# Altium schematic sheet Main.SchDoc
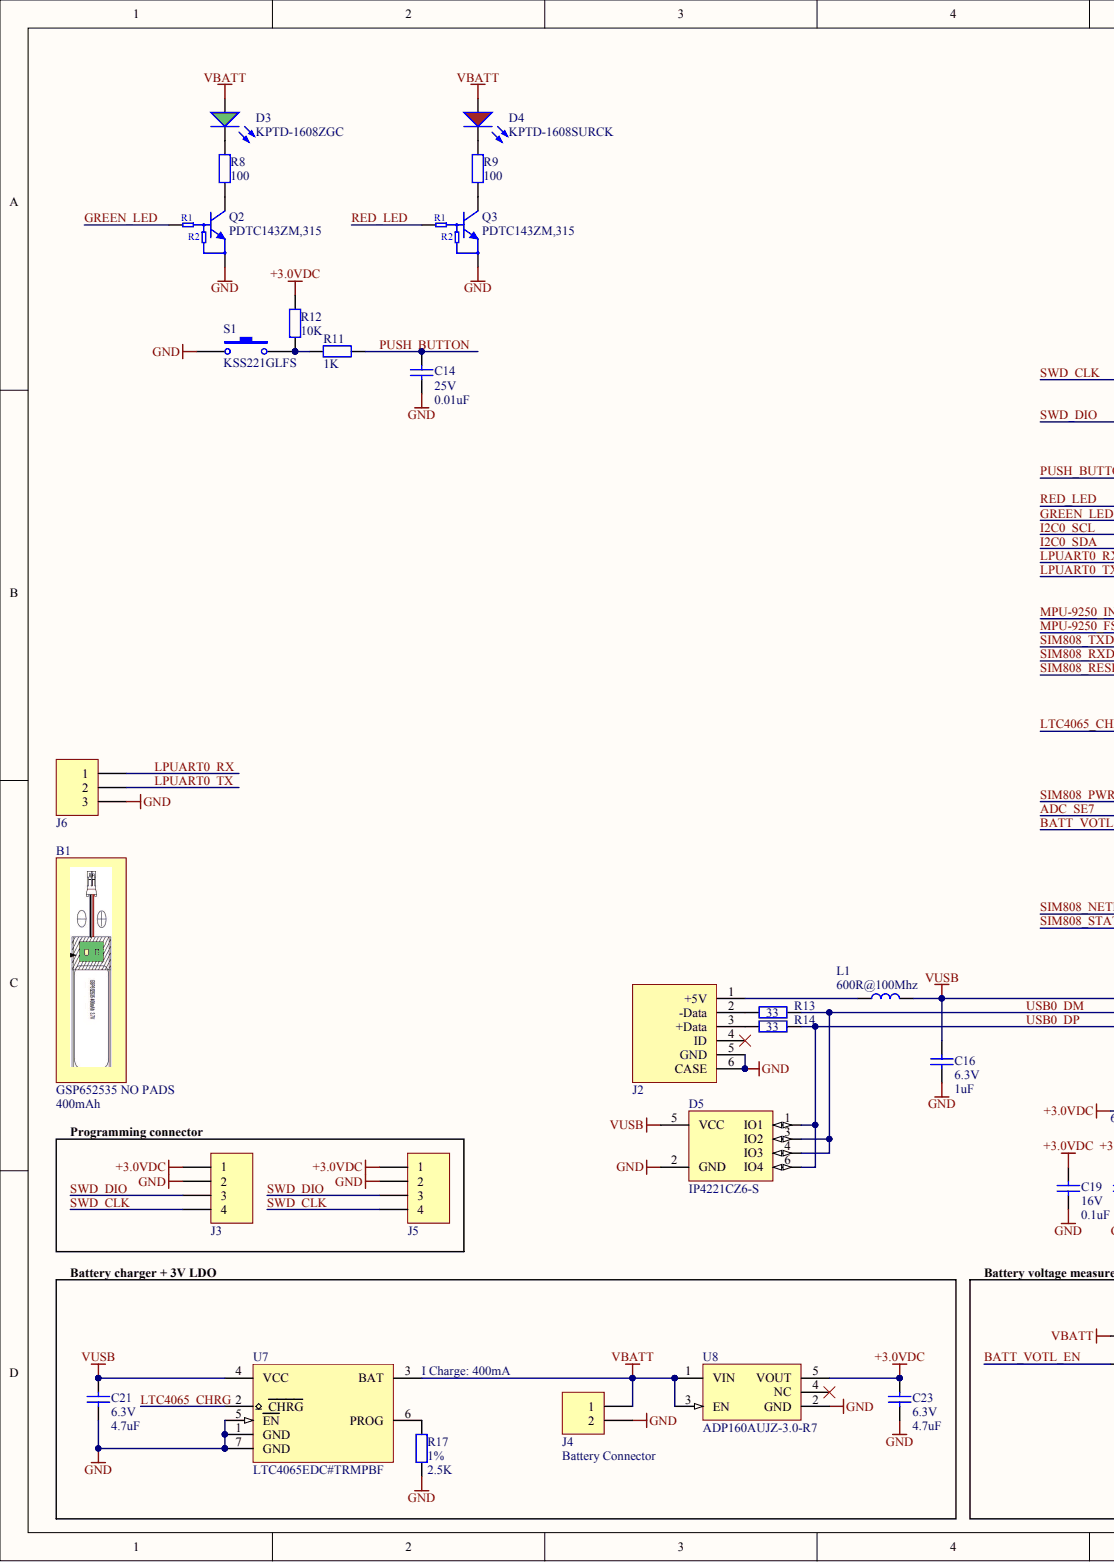
[diagram p0: Main.SchDoc, left]
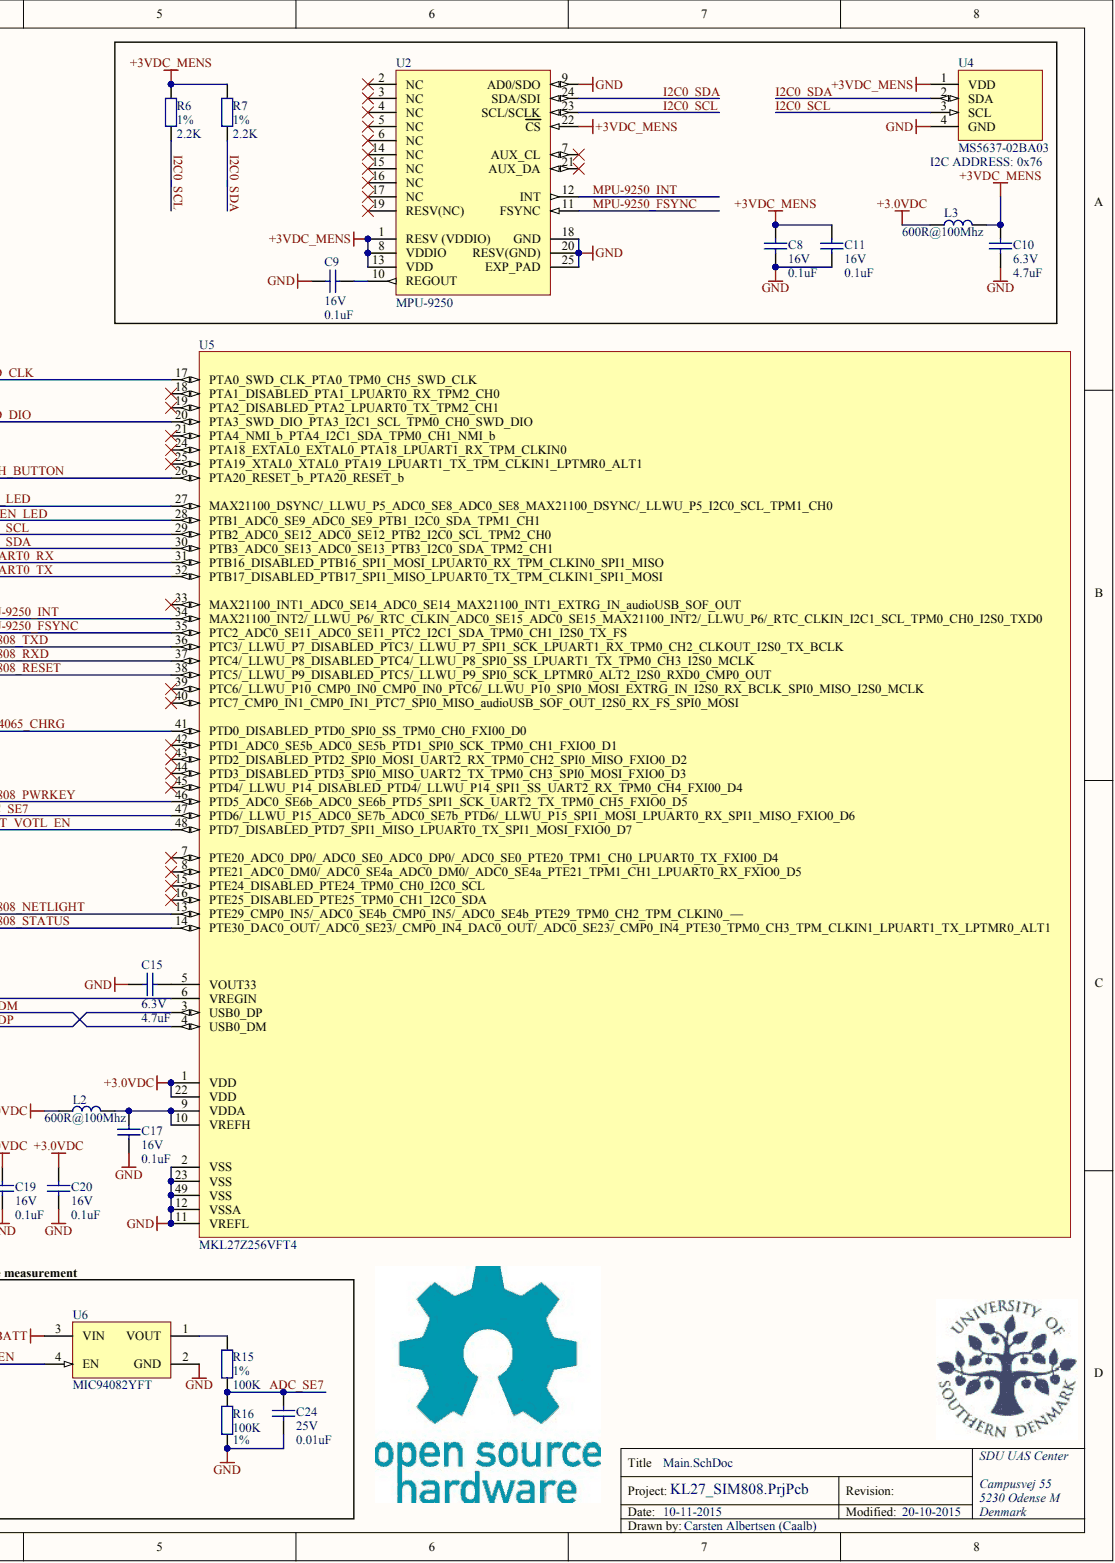
[diagram p1: Main.SchDoc, right]

## Parts
B1  GSP652535 NO PADS  [GSP652535 NO PADS 2.6mm up]
C8  16V  value 0.1uF  [0402C]
C9  16V  value 0.1uF  [0402C]
C10  6.3V  value 4.7uF  [0402C]
C11  16V  value 0.1uF  [0402C]
C14  25V  value 0.01uF  [0402C]
C15  6.3V  value 4.7uF  [0402C]
C16  6.3V  value 1uF  [0402C]
C17  16V  value 0.1uF  [0402C]
C19  16V  value 0.1uF  [0402C]
C20  16V  value 0.1uF  [0402C]
C21  6.3V  value 4.7uF  [0402C]
C23  6.3V  value 4.7uF  [0402C]
C24  25V  value 0.01uF  [0402C]
D3  KPTD-1608ZGC  [LED0603G]
D4  KPTD-1608SURCK  [LED0603R]
D5  IP4221CZ6-S  [SOT886]
J2  Micro USB B  [47346-0001]  (value not drawn)
J3  1x4 Header  [4_Progo_Pin_1.27]  (value not drawn)
J4  1x2 Header  [2x1 Pads 2x2mm SMD]  (value not drawn)
J5  1x4 Header  [4 x 2.54 Pads TOP]  (value not drawn)
J6  1x3 Header  [3 x 2.54 Pads TOP]  (value not drawn)
L1  value 600R@100Mhz  [0402L]  (hidden comment: BK1005HW601-T)
L2  value 600R@100Mhz  [0402L]  (hidden comment: BK1005HW601-T)
L3  value 600R@100Mhz  [0402L]  (hidden comment: BK1005HW601-T)
Q2  PDTC143ZM,315  [SOT883]
Q3  PDTC143ZM,315  [SOT883]
R6  1%  value 2.2K  [0402R]
R7  1%  value 2.2K  [0402R]
R8  1%  value 100  [0402R]
R9  1%  value 100  [0402R]
R11  1%  value 1K  [0402R]
R12  1%  value 10K  [0402R]
R13  value 33  [0402R]  (hidden comment: 1%)
R14  value 33  [0402R]  (hidden comment: 1%)
R15  1%  value 100K  [0402R]
R16  1%  value 100K  [0402R]
R17  1%  value 2.5K  [0402R]
S1  KSS221GLFS  [KSS221G LFS]
U2  MPU-9250  [QFN40P300X300X100_HS-25N]
U4  MS5637-02BA03  [MS5637]
U5  MKL27Z256VFT4  [qfn48 7x7]
U6  MIC94082YFT  [MLF 0.85x0.85]
U7  LTC4065EDC#TRMPBF  [DFN 6 2X2]
U8  ADP160AUJZ-3.0-R7  [SOT23-5]

## Nets
+3.0VDC: C19.2 C20.2 C23.1 J3.1 J5.1 L2.1 L3.1 R12.1 U5.1(VDD) U5.22(VDD) U8.5(VOUT)
+3VDC_MENS: C8.2 C10.2 C11.2 L3.2 R6.1 R7.1 U2.1(RESV_(VDDIO)) U2.8(VDDIO) U2.13(VDD) U2.22(CS) U4.1(VDD)
GND: C8.1 C9.1 C10.1 C11.1 C14.1 C15.2 C16.1 C17.2 C19.1 C20.1 C21.2 C23.2 C24.1 D5.2(GND) J2.5(GND) J2.6(CASE) J3.2 J4.2 J5.2 J6.3 Q2.2 Q3.2 R16.2 R17.2 S1.2 U2.9(AD0/SDO) U2.18(GND) U2.20(RESV(GND)) U2.25(EXP_PAD) U4.4(GND) U5.2(VSS) U5.11(VREFL) U5.12(VSSA) U5.23(VSS) U5.49(VSS) U6.2(GND) U7.1(GND) U7.5(EN) U7.7(GND) U8.2(GND)
VBATT: D3.1 D4.1 J4.1 U6.3(VIN) U7.3(BAT) U8.1(VIN) U8.3(EN)
VUSB: C16.2 C21.1 D5.5(VCC) L1.2 U5.6(VREGIN) U7.4(VCC)
ADC_SE7: C24.2 R15.2 R16.1 U5.47(PTD6/_LLWU_P15_ADC0_SE7b_ADC0_SE7b_PTD6/_LLWU_P15_SPI1_MOSI_LPUART0_RX_SPI1_MISO_FXIO0_D6)
BATT_VOTL_EN: U5.48(PTD7_DISABLED_PTD7_SPI1_MISO_LPUART0_TX_SPI1_MOSI_FXIO0_D7) U6.4(EN)
GREEN_LED: Q2.1 U5.28(PTB1_ADC0_SE9_ADC0_SE9_PTB1_I2C0_SDA_TPM1_CH1)
I2C0_SCL: R6.2 U2.23(SCL/SCLK) U4.3(SCL) U5.29(PTB2_ADC0_SE12_ADC0_SE12_PTB2_I2C0_SCL_TPM2_CH0)
I2C0_SDA: R7.2 U2.24(SDA/SDI) U4.2(SDA) U5.30(PTB3_ADC0_SE13_ADC0_SE13_PTB3_I2C0_SDA_TPM2_CH1)
LPUART0_RX: J6.1 U5.31(PTB16_DISABLED_PTB16_SPI1_MOSI_LPUART0_RX_TPM_CLKIN0_SPI1_MISO)
LPUART0_TX: J6.2 U5.32(PTB17_DISABLED_PTB17_SPI1_MISO_LPUART0_TX_TPM_CLKIN1_SPI1_MOSI)
LTC4065_CHRG: U5.41(PTD0_DISABLED_PTD0_SPI0_SS_TPM0_CH0_FXI00_D0) U7.2(CHRG)
MPU-9250_FSYNC: U2.11(FSYNC) U5.35(PTC2_ADC0_SE11_ADC0_SE11_PTC2_I2C1_SDA_TPM0_CH1_I2S0_TX_FS)
MPU-9250_INT: U2.12(INT) U5.34(MAX21100_INT2/_LLWU_P6/_RTC_CLKIN_ADC0_SE15_ADC0_SE15_MAX21100_INT2/_LLWU_P6/_RTC_CLKIN_I2C1_SCL_TPM0_CH0_I2S0_TXD0)
PUSH_BUTTON: C14.2 R11.2 U5.26(PTA20_RESET_b_PTA20_RESET_b)
RED_LED: Q3.1 U5.27(MAX21100_DSYNC/_LLWU_P5_ADC0_SE8_ADC0_SE8_MAX21100_DSYNC/_LLWU_P5_I2C0_SCL_TPM1_CH0)
SIM808_NETLIGHT: U5.13(PTE29_CMP0_IN5/_ADC0_SE4b_CMP0_IN5/_ADC0_SE4b_PTE29_TPM0_CH2_TPM_CLKIN0_—)
SIM808_PWRKEY: U5.46(PTD5_ADC0_SE6b_ADC0_SE6b_PTD5_SPI1_SCK_UART2_TX_TPM0_CH5_FXIO0_D5)
SIM808_RESET: U5.38(PTC5/_LLWU_P9_DISABLED_PTC5/_LLWU_P9_SPI0_SCK_LPTMR0_ALT2_I2S0_RXD0_CMP0_OUT)
SIM808_RXD: U5.37(PTC4/_LLWU_P8_DISABLED_PTC4/_LLWU_P8_SPI0_SS_LPUART1_TX_TPM0_CH3_I2S0_MCLK)
SIM808_STATUS: U5.14(PTE30_DAC0_OUT/_ADC0_SE23/_CMP0_IN4_DAC0_OUT/_ADC0_SE23/_CMP0_IN4_PTE30_TPM0_CH3_TPM_CLKIN1_LPUART1_TX_LPTMR0_ALT1)
SIM808_TXD: U5.36(PTC3/_LLWU_P7_DISABLED_PTC3/_LLWU_P7_SPI1_SCK_LPUART1_RX_TPM0_CH2_CLKOUT_I2S0_TX_BCLK)
SWD_CLK: J3.4 J5.4 U5.17(PTA0_SWD_CLK_PTA0_TPM0_CH5_SWD_CLK)
SWD_DIO: J3.3 J5.3 U5.20(PTA3_SWD_DIO_PTA3_I2C1_SCL_TPM0_CH0_SWD_DIO)
USB0_DM: D5.3(IO2) D5.4(IO3) R13.2 U5.4(USB0_DM)
USB0_DP: D5.1(IO1) D5.6(IO4) R14.2 U5.3(USB0_DP)
NetC9_2: C9.2 U2.10(REGOUT)
NetC15_1: C15.1 U5.5(VOUT33)
NetC17_1: C17.1 L2.2 U5.9(VDDA) U5.10(VREFH)
NetD3_2: D3.2 R8.1
NetD4_2: D4.2 R9.1
NetJ2_1: J2.1(+5V) L1.1
NetJ2_2: J2.2(-Data) R13.1
NetJ2_3: J2.3(+Data) R14.1
NetQ2_3: Q2.3 R8.2
NetQ3_3: Q3.3 R9.2
NetR11_1: R11.1 R12.2 S1.1
NetR15_1: R15.1 U6.1(VOUT)
NetR17_1: R17.1 U7.6(PROG)

Not connected: J2 4(ID); U2 2(NC) 3(NC) 4(NC) 5(NC) 6(NC) 7(AUX_CL) 14(NC) 15(NC) 16(NC) 17(NC) 19(RESV(NC)) 21(AUX_DA); U5 7(PTE20_ADC0_DP0/_ADC0_SE0_ADC0_DP0/_ADC0_SE0_PTE20_TPM1_CH0_LPUART0_TX_FXI00_D4) 8(PTE21_ADC0_DM0/_ADC0_SE4a_ADC0_DM0/_ADC0_SE4a_PTE21_TPM1_CH1_LPUART0_RX_FXIO0_D5) 15(PTE24_DISABLED_PTE24_TPM0_CH0_I2C0_SCL) 16(PTE25_DISABLED_PTE25_TPM0_CH1_I2C0_SDA) 18(PTA1_DISABLED_PTA1_LPUART0_RX_TPM2_CH0) 19(PTA2_DISABLED_PTA2_LPUART0_TX_TPM2_CH1) 21(PTA4_NMI_b_PTA4_I2C1_SDA_TPM0_CH1_NMI_b) 24(PTA18_EXTAL0_EXTAL0_PTA18_LPUART1_RX_TPM_CLKIN0) 25(PTA19_XTAL0_XTAL0_PTA19_LPUART1_TX_TPM_CLKIN1_LPTMR0_ALT1) 33(MAX21100_INT1_ADC0_SE14_ADC0_SE14_MAX21100_INT1_EXTRG_IN_audioUSB_SOF_OUT) 39(PTC6/_LLWU_P10_CMP0_IN0_CMP0_IN0_PTC6/_LLWU_P10_SPI0_MOSI_EXTRG_IN_I2S0_RX_BCLK_SPI0_MISO_I2S0_MCLK) 40(PTC7_CMP0_IN1_CMP0_IN1_PTC7_SPI0_MISO_audioUSB_SOF_OUT_I2S0_RX_FS_SPI0_MOSI) 42(PTD1_ADC0_SE5b_ADC0_SE5b_PTD1_SPI0_SCK_TPM0_CH1_FXIO0_D1) 43(PTD2_DISABLED_PTD2_SPI0_MOSI_UART2_RX_TPM0_CH2_SPI0_MISO_FXIO0_D2) 44(PTD3_DISABLED_PTD3_SPI0_MISO_UART2_TX_TPM0_CH3_SPI0_MOSI_FXIO0_D3) 45(PTD4/_LLWU_P14_DISABLED_PTD4/_LLWU_P14_SPI1_SS_UART2_RX_TPM0_CH4_FXI00_D4); U8 4(NC)

## Library data of the parts
C8 C9 C11 C17 C19 C20 = Cap_0402_.1uF: Capacitor, SMD, 0402, X7R; Component Kind: Standard; Signal Integrity: Cap; Simulation: CAP; Supplier 1: RS-Components; Supplier 2: Farnell; Supplier 3: Digi-Key; Supplier 4: Mouser; Library Name: Ofte_Benyttede.SCHLIB
C10 C21 C23 = Cap_0402_4.7uF: Capacitor, SMD, 0402, X5R; Component Kind: Standard; Signal Integrity: Cap; Simulation: CAP; Supplier 1: RS-Components; Supplier 2: Farnell; Supplier 3: Digi-Key; Supplier 4: Mouser; Supplier Part Number 1: 758-2764; Supplier Part Number 3: 1276-1056-1-ND; Library Name: Ofte_Benyttede.SCHLIB
C14 C24 = Cap_0402_.01uF: Capacitor, SMD, 0402, X7R; Component Kind: Standard; Signal Integrity: Cap; Simulation: CAP; Supplier 1: RS-Components; Supplier 2: Farnell; Supplier 3: Digi-Key; Supplier 4: Mouser; Supplier Part Number 2: 2070373; Library Name: Ofte_Benyttede.SCHLIB
C15 = Cap_0402_4.7uF: Capacitor, SMD, 0402, X5R; Value: 4.7uF; Component Kind: Standard; Signal Integrity: Cap; Simulation: CAP; Supplier 1: RS-Components; Supplier 2: Farnell; Supplier 3: Digi-Key; Supplier 4: Mouser; Supplier Part Number 1: 758-2764; Supplier Part Number 3: 1276-1056-1-ND; Library Name: Ofte_Benyttede.SCHLIB
C16 = Cap_0402_1uF: Capacitor, SMD, 0402, X5R; Component Kind: Standard; Signal Integrity: Cap; Simulation: CAP; Supplier 1: RS-Components; Supplier 2: Farnell; Supplier 3: Digi-Key; Supplier 4: Mouser; Library Name: Ofte_Benyttede.SCHLIB
D3 = LED_Green: LED,SMD,True-Green, 1000mcd@20mA; Lager: No; Component Kind: Standard; PN Farnell: 2217986; Library Name: Ofte_Benyttede.SCHLIB
D4 = LED_Red: LED,SMD,Hyper Red, 800mcd@20mA; Lager: No; Component Kind: Standard; PN Farnell: 2099232; Library Name: Ofte_Benyttede.SCHLIB
D5 = IP4221CZ6-S: ESD protection for high-speed interfaces; Component Kind: Standard; ComponentLink1Description: http://www.nxp.com/documents/data_sheet/IP4221CZ6-S.pdf; ComponentLink1URL: http://www.nxp.com/documents/data_sheet/IP4221CZ6-S.pdf; Supplier 1: RS-Components; Supplier 2: Farnell; Supplier 3: Digi-Key; Supplier 4: Mouser; Supplier Part Number 1: 725-8789; Supplier Part Number 2: 1829274; Supplier Part Number 3: 568-6340-6-ND; Library Name: Philips_egne.SchLib
J2 = 47346-0001: USB Micro B, Receptacle, Bottom Mount; Component Kind: Standard; ComponentLink1Description: http://www.molex.com/pdm_docs/sd/473460001_sd.pdf; ComponentLink1URL: http://www.molex.com/pdm_docs/sd/473460001_sd.pdf; Supplier 1: RS-Components; Supplier 2: Farnell; Supplier 3: Digi-Key; Supplier 4: Mouser; Supplier Part Number 1: 702-5475; Supplier Part Number 2: 1568026; Supplier Part Number 3: WM17141CT-ND; Library Name: Connector_Div_egne.SchLib
J3 J5 = 1x4 Header: Header Male 1x4; Component Kind: Standard; Supplier 1: RS-Components; Supplier 2: Farnell; Supplier 3: Digi-Key; Supplier 4: Mouser; Library Name: Connector_Div_egne.SchLib
J4 = 1x2 Header: Header Male 1x2; Component Kind: Standard; Supplier 1: RS-Components; Supplier 2: Farnell; Supplier 3: Digi-Key; Supplier 4: Mouser; Library Name: Connector_Div_egne.SchLib
J6 = 1x3 Header: Header Male 1x3; Component Kind: Standard; Supplier 1: RS-Components; Supplier 2: Farnell; Supplier 3: Digi-Key; Supplier 4: Mouser; Library Name: Connector_Div_egne.SchLib
L1-L3 = Ferrite_0402: Ferrite bead 300mA, 600mOhm, 600R@100Mhz; Component Kind: Standard; ComponentLink1Description: http://www.yuden.co.jp/ut/product/category/emisuppression/BK1005HW601-T.pdf; ComponentLink1URL: http://www.yuden.co.jp/ut/product/category/emisuppression/BK1005HW601-T.pdf; Supplier 1: RS-Components; Supplier 2: Farnell; Supplier 3: Digi-Key; Supplier 4: Mouser; Supplier Part Number 2: 1651725; Supplier Part Number 3: 587-1851-1-ND; Library Name: Ofte_Benyttede.SCHLIB
Q2 Q3 = PDTC143ZM,315: NPN 100 mA, 50 V resistor-equipped transistor 4.7K 47K; Component Kind: Standard; Supplier 1: RS-Components; Supplier 2: Farnell; Supplier 3: Digi-Key; Supplier 4: Mouser; Supplier Part Number 3: 568-2167-6-ND; Library Name: Philips_egne.SchLib
R6 R7 R11-R17 = Res_0402: Resistor, SMD, 0402, 0.063W; Component Kind: Standard; Signal Integrity: Res; Simulation: RESISTOR; Supplier 1: RS-Components; Supplier 2: Farnell; Supplier 3: Digi-Key; Supplier 4: Mouser; Library Name: Ofte_Benyttede.SCHLIB
R8 R9 = Res_0402: Resistor, SMD, 0402, 0.063W; Lager: No; Component Kind: Standard; Signal Integrity: Res; Simulation: RESISTOR; Library Name: Ofte_Benyttede.SCHLIB
S1 = SW-PB: SWITCH TACT SILVR 200GF GULLWING; Manufacturer: C&K Components; Actuator Type: Rectangular Button; Circuit: SPST-NO; Contact Rating @ Voltage: 0.05A @ 50VDC; Illumination: Non-Illuminated; Lager: No; Outline: 7.00mm x 3.50mm; Switch Function: Off-Mom; Category: Switches; Component Kind: Standard; LatestRevisionDate: 17-Jul-2002; LatestRevisionNote: Re-released for DXP Platform.; Library Name: Miscellaneous Devices.IntLib; Manufacturer Part Number: KSS221GLFS; Mounting Type: Surface Mount; Operating Force: 200gf; Orientation: Right Angle; PackageReference: SPST-2; PN Digikey: 401-1097-6-ND; Published: 24-Mar-1999; RoHS: RoHS Compliant; Supplier: Digi-Key; Publisher: Altium Limited
U2 = MPU-9250: MPU-9250 Nine-Axis (Gyro + Accelerometer + Compass) MEMS MotionTracking™ Device; Component Kind: Standard; ComponentLink1Description: http://media.digikey.com/pdf/Data%20Sheets/InvenSense%20PDFs/MPU-9250.pdf; ComponentLink1URL: http://media.digikey.com/pdf/Data%20Sheets/InvenSense%20PDFs/MPU-9250.pdf; Supplier 1: RS-Components; Supplier 2: Farnell; Supplier 3: Digi-Key; Supplier 4: Mouser; Supplier Part Number 3: 1428-1019-1-ND; Library Name: DivLib.SCHLIB
U4 = MS5637-02BA03: Low Voltage Barometric Pressure Sensor; Component Kind: Standard; ComponentLink1Description: http://www.meas-spec.com/downloads/MS5637-02BA03.pdf; ComponentLink1URL: http://www.meas-spec.com/downloads/MS5637-02BA03.pdf; Supplier 1: RS-Components; Supplier 2: Farnell; Supplier 3: Digi-Key; Supplier 4: Mouser; Supplier Part Number 2: 2362663; Supplier Part Number 3: 223-1199-1-ND; Library Name: DivLib.SCHLIB
U5 = MKL27Z256VFT4: Kinetis KL27 Microcontroller, 48 MHz ARM® Cortex®-M0+ and 256 KB Flash; Component Kind: Standard; ComponentLink1Description: http://cache.freescale.com/files/32bit/doc/data_sheet/KL27P64M48SF6.pdf; ComponentLink1URL: http://cache.freescale.com/files/32bit/doc/data_sheet/KL27P64M48SF6.pdf; Supplier 1: RS-Components; Supplier 2: Farnell; Supplier 3: Digi-Key; Supplier 4: Mouser; Supplier Part Number 3: MKL27Z256VFT4-ND; Library Name: KinetisKL27_egne.SchLib
U6 = MIC94082YFT: Load Switch, 2A, 67m Ohm, Pulldown; Component Kind: Standard; ComponentLink1Description: http://www.farnell.com/datasheets/1833756.pdf; ComponentLink1URL: http://www.farnell.com/datasheets/1833756.pdf; Supplier 1: RS-Components; Supplier 2: Farnell; Supplier 3: Digi-Key; Supplier 4: Mouser; Supplier Part Number 2: 2431860; Supplier Part Number 3: 576-3342-1-ND; Supplier Part Number 4: 998-MIC94082YFTTR; Library Name: Micrell_egne.SchLib
U7 = LTC4065EDC#TRMPBF: Standalone 750mA Li-Ion  Battery Charger in 2 × 2 DFN; Component Kind: Standard; ComponentLink1Description: http://www.farnell.com/datasheets/1441932.pdf; ComponentLink1URL: http://www.farnell.com/datasheets/1441932.pdf; Supplier 1: RS-Components; Supplier 2: Farnell; Supplier 3: Digi-Key; Supplier 4: Mouser; Supplier Part Number 1: 822-5935; Supplier Part Number 2: 1663910; Supplier Part Number 3: LTC4065EDC#TRMPBFCT-ND; Library Name: Linear_egne.SchLib
U8 = ADP160AUJZ-3.0-R7: Ultralow Quiescent Current,  150 mA, CMOS Linear Regulators; Component Kind: Standard; ComponentLink1Description: http://www.analog.com/static/imported-files/data_sheets/ADP160_161_162_163.pdf; ComponentLink1URL: http://www.analog.com/static/imported-files/data_sheets/ADP160_161_162_163.pdf; Supplier 1: RS-Components; Supplier 2: Farnell; Supplier 3: Digi-Key; Supplier 4: Mouser; Supplier Part Number 3: ADP160AUJZ-3.0-R7CT-ND; Library Name: Analog_egne.SchLib
B1 = GSP652535 NO PADS: Component Kind: Standard; Description: GSP652535 NO PADS; Supplier 1: RS-Components; Supplier 2: Farnell; Supplier 3: Digi-Key; Supplier 4: Mouser; Library Name: DivLib.SCHLIB
